# Altium schematic sheet ignition-adapter.SchDoc
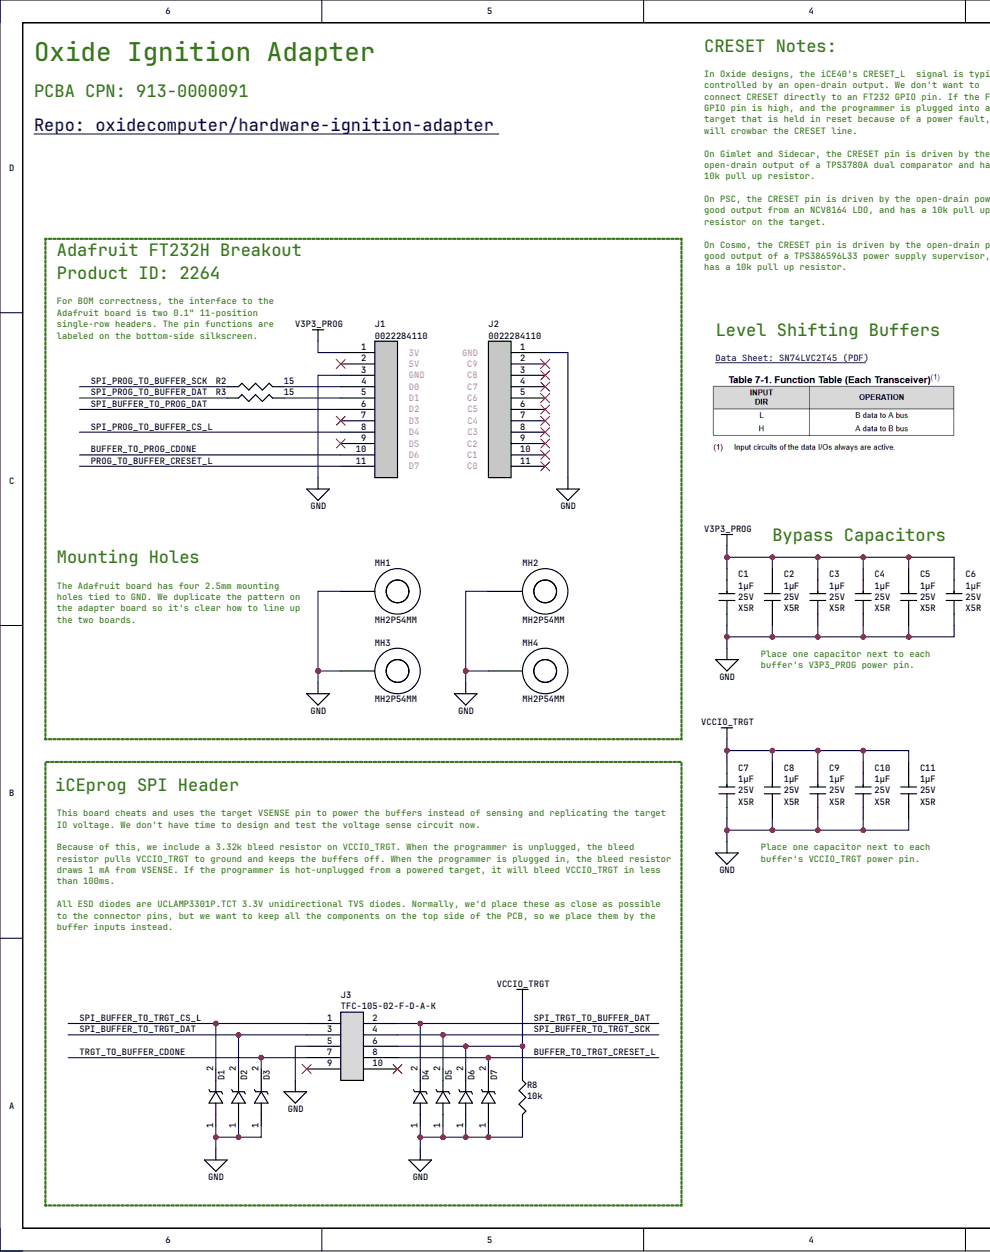
[diagram p0: ignition-adapter.SchDoc, left]
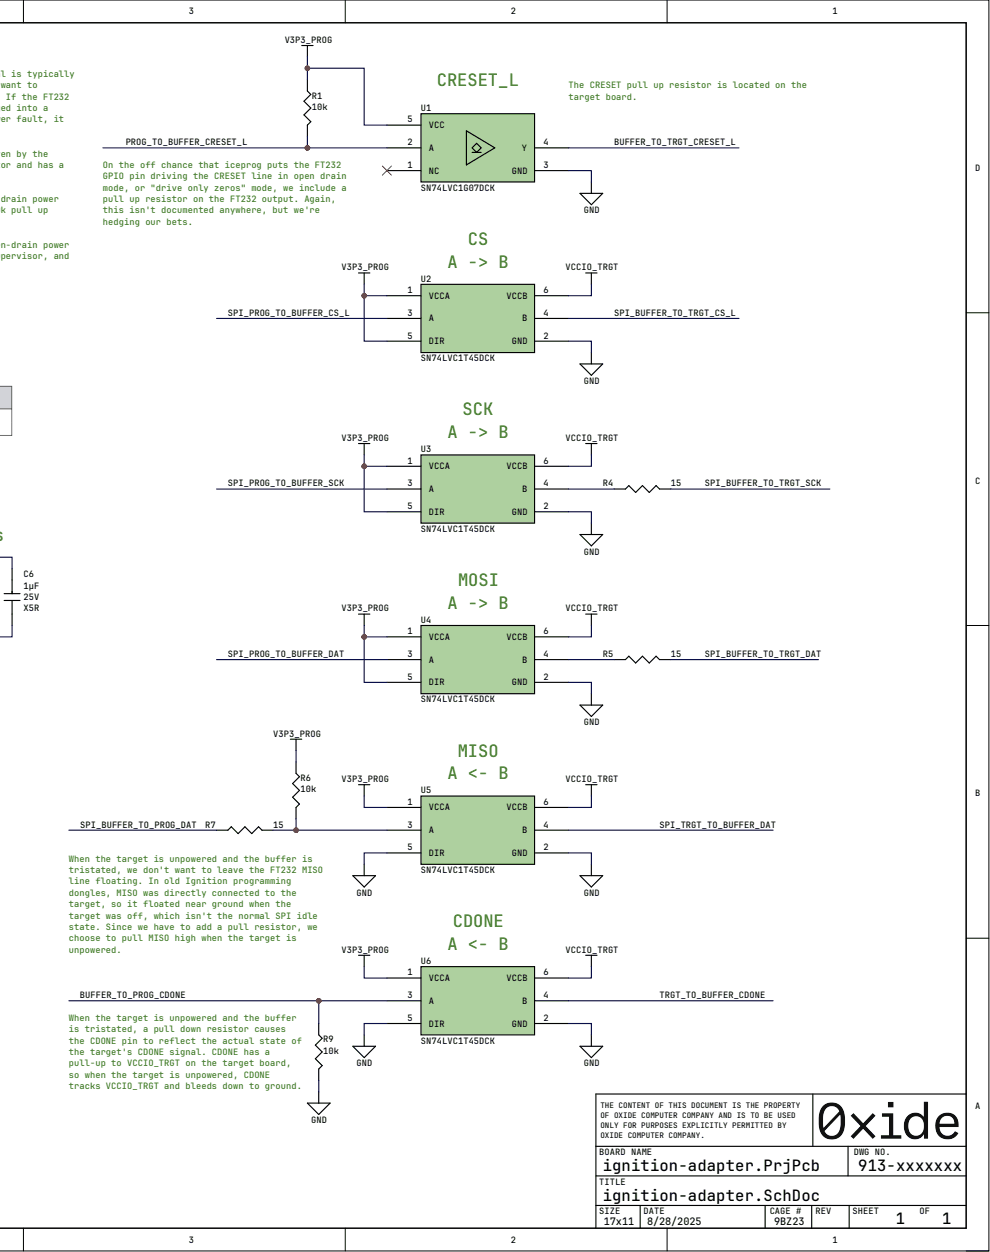
[diagram p1: ignition-adapter.SchDoc, right]

## Parts
C1  value 1µF  Dielectric Class: X5R  Voltage: 25V  [CAPC1005X06N]  (hidden comment: GRM155R61E105KE11J)
C2  value 1µF  Dielectric Class: X5R  Voltage: 25V  [CAPC1005X06N]  (hidden comment: GRM155R61E105KE11J)
C3  value 1µF  Dielectric Class: X5R  Voltage: 25V  [CAPC1005X06N]  (hidden comment: GRM155R61E105KE11J)
C4  value 1µF  Dielectric Class: X5R  Voltage: 25V  [CAPC1005X06N]  (hidden comment: GRM155R61E105KE11J)
C5  value 1µF  Dielectric Class: X5R  Voltage: 25V  [CAPC1005X06N]  (hidden comment: GRM155R61E105KE11J)
C6  value 1µF  Dielectric Class: X5R  Voltage: 25V  [CAPC1005X06N]  (hidden comment: GRM155R61E105KE11J)
C7  value 1µF  Dielectric Class: X5R  Voltage: 25V  [CAPC1005X06N]  (hidden comment: GRM155R61E105KE11J)
C8  value 1µF  Dielectric Class: X5R  Voltage: 25V  [CAPC1005X06N]  (hidden comment: GRM155R61E105KE11J)
C9  value 1µF  Dielectric Class: X5R  Voltage: 25V  [CAPC1005X06N]  (hidden comment: GRM155R61E105KE11J)
C10  value 1µF  Dielectric Class: X5R  Voltage: 25V  [CAPC1005X06N]  (hidden comment: GRM155R61E105KE11J)
C11  value 1µF  Dielectric Class: X5R  Voltage: 25V  [CAPC1005X06N]  (hidden comment: GRM155R61E105KE11J)
D1  UCLAMP3301P.TCT  [SEMTECH_SLP1006P2]
D2  UCLAMP3301P.TCT  [SEMTECH_SLP1006P2]
D3  UCLAMP3301P.TCT  [SEMTECH_SLP1006P2]
D4  UCLAMP3301P.TCT  [SEMTECH_SLP1006P2]
D5  UCLAMP3301P.TCT  [SEMTECH_SLP1006P2]
D6  UCLAMP3301P.TCT  [SEMTECH_SLP1006P2]
D7  UCLAMP3301P.TCT  [SEMTECH_SLP1006P2]
J1  0022284110  [0022284110-Footprint-1]
J2  0022284110  [0022284110-Footprint-1]
J3  TFC-105-02-F-D-A-K  [SAMTEC_TFC-105-02-F-D-A-K]
MH1  MH2P54MM  [MH2P54MM-Footprint-1]
MH2  MH2P54MM  [MH2P54MM-Footprint-1]
MH3  MH2P54MM  [MH2P54MM-Footprint-1]
MH4  MH2P54MM  [MH2P54MM-Footprint-1]
R1  value 10k  [RESC1005X04N]  (hidden comment: RES 10K Ohm 1.0% 0.0625W 0402)
R2  value 15  [RESC1005X04N]  (hidden comment: RES 15R Ohm 1.0% 0.0625W 0402)
R3  value 15  [RESC1005X04N]  (hidden comment: RES 15R Ohm 1.0% 0.0625W 0402)
R4  value 15  [RESC1005X04N]  (hidden comment: RES 15R Ohm 1.0% 0.0625W 0402)
R5  value 15  [RESC1005X04N]  (hidden comment: RES 15R Ohm 1.0% 0.0625W 0402)
R6  value 10k  [RESC1005X04N]  (hidden comment: RES 10K Ohm 1.0% 0.0625W 0402)
R7  value 15  [RESC1005X04N]  (hidden comment: RES 15R Ohm 1.0% 0.0625W 0402)
R8  value 10k  [RESC1005X04N]  (hidden comment: RES 10K Ohm 1.0% 0.0625W 0402)
R9  value 10k  [RESC1005X04N]  (hidden comment: RES 10K Ohm 1.0% 0.0625W 0402)
U1  SN74LVC1G07DCK  [TI_DCK0005A]
U2  SN74LVC1T45DCK  [TI_DCK0006A]
U3  SN74LVC1T45DCK  [TI_DCK0006A]
U4  SN74LVC1T45DCK  [TI_DCK0006A]
U5  SN74LVC1T45DCK  [TI_DCK0006A]
U6  SN74LVC1T45DCK  [TI_DCK0006A]

## Nets
GND: C1.2 C2.2 C3.2 C4.2 C5.2 C6.2 C7.2 C8.2 C9.2 C10.2 C11.2 D1.1 D2.1 D3.1 D4.1 D5.1 D6.1 D7.1 J1.3 J2.1 J3.5 MH1.MH MH2.MH MH3.MH MH4.MH R8.2 R9.2 U1.3(GND) U2.2(GND) U3.2(GND) U4.2(GND) U5.2(GND) U5.5(DIR) U6.2(GND) U6.5(DIR)
V3P3_PROG: C1.1 C2.1 C3.1 C4.1 C5.1 C6.1 J1.1 R1.1 R6.1 U1.5(VCC) U2.1(VCCA) U2.5(DIR) U3.1(VCCA) U3.5(DIR) U4.1(VCCA) U4.5(DIR) U5.1(VCCA) U6.1(VCCA)
VCCIO_TRGT: C7.1 C8.1 C9.1 C10.1 C11.1 D6.2 J3.6 R8.1 U2.6(VCCB) U3.6(VCCB) U4.6(VCCB) U5.6(VCCB) U6.6(VCCB)
BUFFER_TO_PROG_CDONE: J1.10 R9.1 U6.3(A)
BUFFER_TO_TRGT_CRESET_L: D7.2 J3.8 U1.4(Y)
PROG_TO_BUFFER_CRESET_L: J1.11 R1.2 U1.2(A)
SPI_BUFFER_TO_PROG_DAT: J1.6 R7.1
SPI_BUFFER_TO_TRGT_CS_L: D1.2 J3.1 U2.4(B)
SPI_BUFFER_TO_TRGT_DAT: D2.2 J3.3 R5.2
SPI_BUFFER_TO_TRGT_SCK: D5.2 J3.4 R4.2
SPI_PROG_TO_BUFFER_CS_L: J1.8 U2.3(A)
SPI_PROG_TO_BUFFER_DAT: R3.1 U4.3(A)
SPI_PROG_TO_BUFFER_SCK: R2.1 U3.3(A)
SPI_TRGT_TO_BUFFER_DAT: D4.2 J3.2 U5.4(B)
TRGT_TO_BUFFER_CDONE: D3.2 J3.7 U6.4(B)
NetJ1_4: J1.4 R2.2
NetJ1_5: J1.5 R3.2
NetR4_1: R4.1 U3.4(B)
NetR5_1: R5.1 U4.4(B)
NetR6_2: R6.2 R7.2 U5.3(A)

Not connected: J1 2 7 9; J2 2 3 4 5 6 7 8 9 10 11; J3 9 10; U1 1(NC)

## Library data of the parts
C1-C11 = CMP-00433-28: CAP MLCC 1µF ±10% 25V X5R 0402 0.020in; Manufacturer: Murata; CPN: 212-0000173; Kind: Ceramic; max_temp: 85; ruc: Standard; Component Kind: Standard; datasheet: https://drive.google.com/file/d/124opgVhcHugMcoRgv9tywCJIUFcP1P69/view?usp=sharing; height: 0.6; Manufacturer PN: GRM155R61E105KE11J; Package: 0402; plm_link: https://mfg.duro.app/component/view/60ecaca7cfd44e00083bd5a9; Tolerance: 10%; Library Name: Oxide Computer Company
D1-D7 = CMP-004-00020-7: Diode Unidirectional TVS 1ch 3.3V 20kV Air 15kV Contact, 5A EFT, 25pF; Manufacturer: Semtech; CPN: 217-0000023; i_max: 5; mount_type: Smt; reverse_voltage: 3.3; ruc: Standard; Component Kind: Standard; Current: 5A; datasheet: https://drive.google.com/file/d/1luftkSnR9r75oXmnGM-DsnVsuCtPCwF-/view?usp=sharing; height: 0.55; kind: TVS; Manufacturer PN: UCLAMP3301P.TCT; Package: SLP1006P2; plm_link: https://mfg.duro.app/component/view/65f06d983d4ec20008369578; Reverse Voltage: 3.3V; Library Name: Oxide Computer Company
J1 J2 = CMP-002-00109-1: Connector Header Through Hole 11 position 0.100" (2.54mm); Manufacturer: Molex; CPN: 215-0000195; Component Kind: Standard; Manufacturer PN: 0022284110; Library Name: Oxide Computer Company
J3 = CMP-002-00009-21: .050 X .050 TERMINAL STRIP; Manufacturer: Samtec; contact_plating: Gold; contact_type: Pin; CPN: 215-0000068; current_rating: 1.7; keyed: True; mount_direction: vertical; mount_style: Smt; num_contacts: 10; num_rows: 2; pin_pitch: 1.27; plating_thickness: 0.0762; retention_mech: None; ruc: Standard; trade_name: TFC; voltage_rating: 219; Component Kind: Standard; datasheet: https://drive.google.com/file/d/1PNZOtTlwjR_iZnEbDkBQg4SdCrA19alR/view?usp=sharing; height: 5.59; Manufacturer PN: TFC-105-02-F-D-A-K; plm_link: https://mfg.duro.app/component/view/6116e6d93ae8d00007eba0fb; Library Name: Oxide Computer Company
MH1-MH4 = CMP-00028-00008-1: 2.54mm PTH mounting hole for Ignition programming adapter board, to match Adafruit product 2264; Component Kind: Standard; Library Name: Oxide Computer Company
R1 R6 R8 R9 = CMP-009-02109-22: CPN: 232-0000125; mount_style: Smt; Power: 62.5mW; ruc: Standard; temp_co: 100; Tolerance: 1%; Voltage Rating: 50V; voltage_rating: 50; Case/Package: 0402; Component Kind: Standard; Description: RES 10K Ohm 1.0% 0.0625W 0402; height: 0.4; Mounting Technology: ThickFilm; Package: 0402; plm_link: https://mfg.duro.app/component/view/6116e6cf419c1f0008e909cd; technology: ThickFilm; Library Name: Oxide Computer Company
R2-R5 R7 = CMP-009-02654-23: CPN: 232-0001345; mount_style: Smt; Power: 62.5mW; ruc: Standard; temp_co: 100; Tolerance: 1%; Voltage Rating: 50V; voltage_rating: 50; Case/Package: 0402; Component Kind: Standard; Description: RES 15R Ohm 1.0% 0.0625W 0402; height: 0.4; Mounting Technology: ThickFilm; Package: 0402; plm_link: https://mfg.duro.app/component/view/64c2cb89cdd7cc00086473d8; technology: ThickFilm; Library Name: Oxide Computer Company
U1 = CMP-031-00250-7: Buffer, Non-Inverting 1 Element 1 Bit per Element Open Drain Output SC-70-5; Manufacturer: Texas Instruments; Manufacturer PN: SN74LVC1G07DCKR; CPN: 221-0000199; max_temp: 125; min_temp: -40; pitch: 0.65; ruc: Standard; voltage: 1.65-5.5; Component Kind: Standard; datasheet: https://drive.google.com/file/d/1bIZDR_QQu0wFV7Qqf2eSD1MJTg4tsIyE/view?usp=drive_link; height: 1.1; length: 2.15; Package: SC-70-5; plm_link: https://mfg.duro.app/component/view/660417f05ccfcb0008afc187; width: 2.4; Library Name: Oxide Computer Company
U2-U6 = CMP-031-00327-2: IC XLTR VL BIDIR SC-70-6; Manufacturer: Texas Instruments; Manufacturer PN: SN74LVC1T45DCKR; CPN: 221-0000227; Component Kind: Standard; Package: SC-70-6; Library Name: Oxide Computer Company
